# Altium schematic sheet Relais sheet.SchDoc
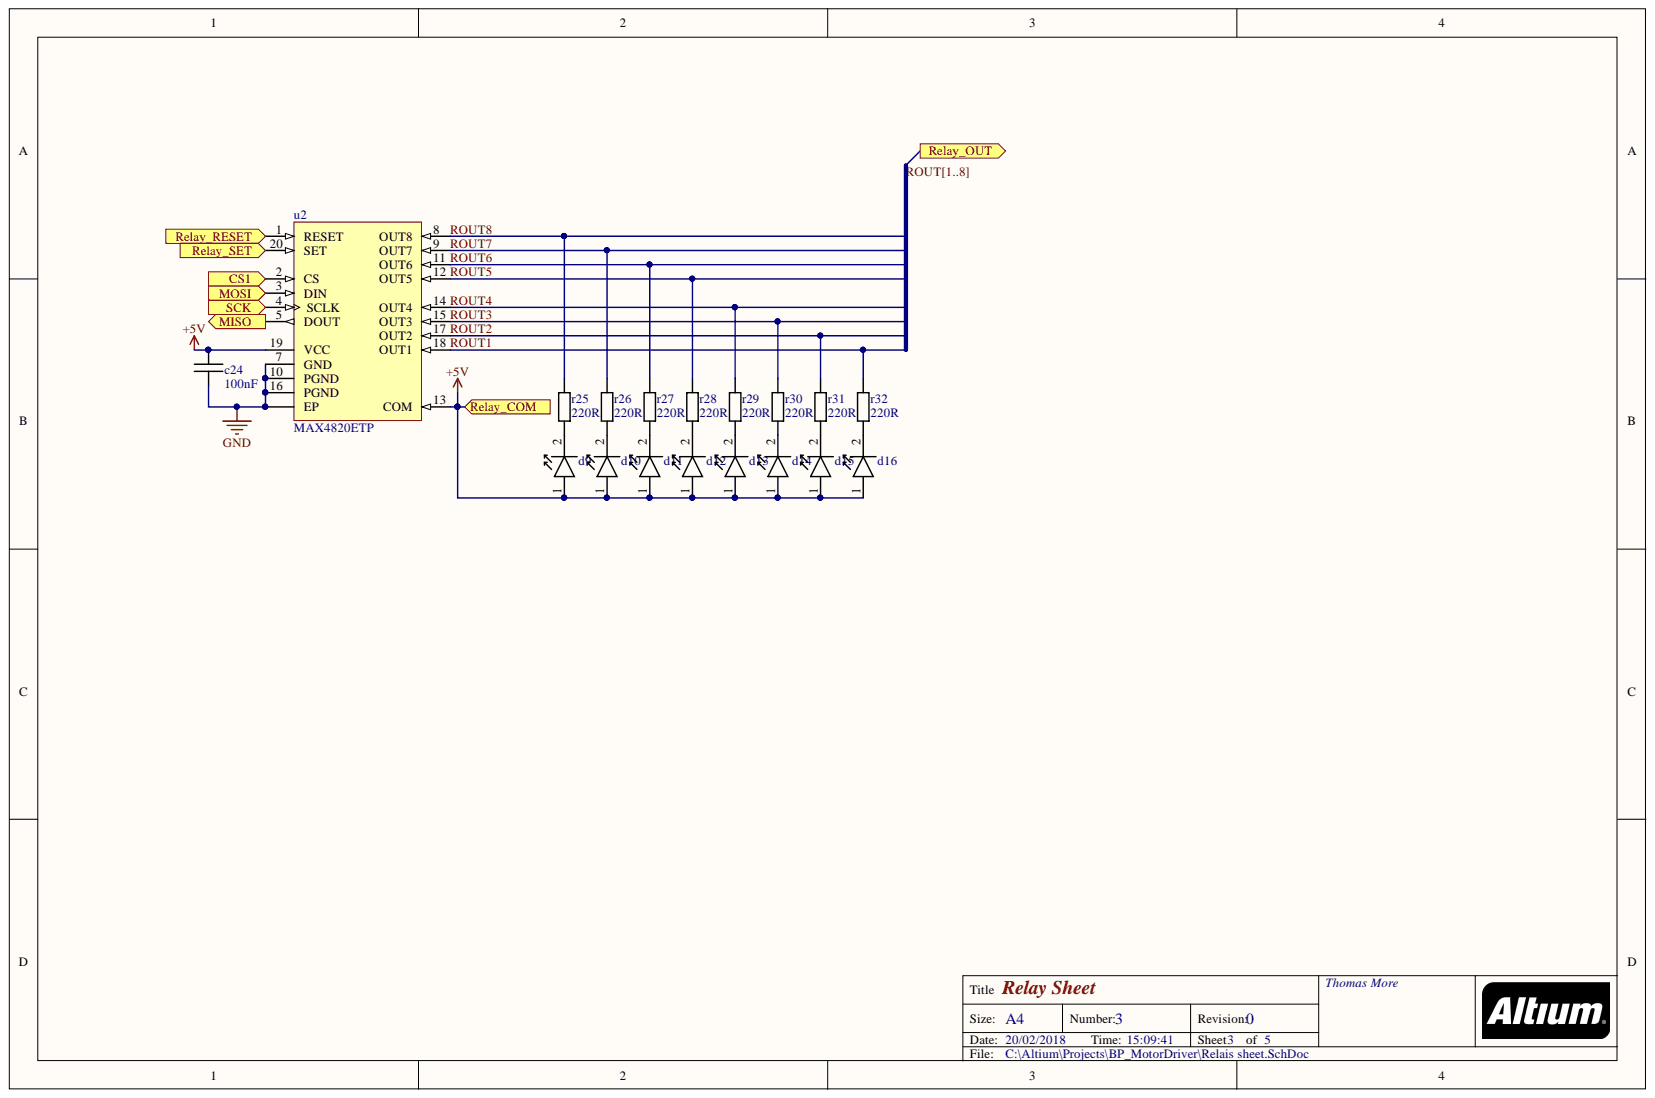
[diagram p0: Relais sheet.SchDoc]

## Parts
c24  100nF  [0805]
d9  Yellow SMD LED  [0603 - LED]  (value not drawn)
d10  Yellow SMD LED  [0603 - LED]  (value not drawn)
d11  Yellow SMD LED  [0603 - LED]  (value not drawn)
d12  Yellow SMD LED  [0603 - LED]  (value not drawn)
d13  Yellow SMD LED  [0603 - LED]  (value not drawn)
d14  Yellow SMD LED  [0603 - LED]  (value not drawn)
d15  Yellow SMD LED  [0603 - LED]  (value not drawn)
d16  Yellow SMD LED  [0603 - LED]  (value not drawn)
r25  220R  [0603]
r26  220R  [0603]
r27  220R  [0603]
r28  220R  [0603]
r29  220R  [0603]
r30  220R  [0603]
r31  220R  [0603]
r32  220R  [0603]
u2  MAX4820ETP  [20 Thin QFN-EP]

## Ports
CS1, MISO, MOSI, Relay_COM, Relay_OUT, Relay_RESET, Relay_SET, SCK

## Nets
GND: c24.2 u2.0 u2.7(GND) u2.10(PGND) u2.16(PGND)
+5V: c24.1 d9.1 d10.1 d11.1 d12.1 d13.1 d14.1 d15.1 d16.1 u2.13(COM) u2.19(VCC) ; port Relay_COM
CS1: u2.2(CS) ; port CS1
MISO: u2.5(DOUT) ; port MISO
MOSI: u2.3(DIN) ; port MOSI
Relay_RESET: u2.1(RESET) ; port Relay_RESET
Relay_SET: u2.20(SET) ; port Relay_SET
ROUT1: r32.2 u2.18(OUT1)  [also labelled ROUT]
ROUT2: r31.2 u2.17(OUT2)  [also labelled ROUT]
ROUT3: r30.2 u2.15(OUT3)  [also labelled ROUT]
ROUT4: r29.2 u2.14(OUT4)  [also labelled ROUT]
ROUT5: r28.2 u2.12(OUT5)  [also labelled ROUT]
ROUT6: r27.2 u2.11(OUT6)  [also labelled ROUT]
ROUT7: r26.2 u2.9(OUT7)  [also labelled ROUT]
ROUT8: r25.2 u2.8(OUT8)  [also labelled ROUT]
SCK: u2.4(SCLK) ; port SCK
Netd9_2: d9.2 r25.1
Netd10_2: d10.2 r26.1
Netd11_2: d11.2 r27.1
Netd12_2: d12.2 r28.1
Netd13_2: d13.2 r29.1
Netd14_2: d14.2 r30.1
Netd15_2: d15.2 r31.1
Netd16_2: d16.2 r32.1
N00224: ; port Relay_OUT

## Library data of the parts
c24 = 0805 Capacitor: ...F; Component Kind: Standard; Library Name: Generic R-C.SchLib
d9-d16 = Yellow SMD LED: 0603 Package; Component Kind: Standard; Library Name: Kingbright.SchLib
r25-r32 = 0603 Resistor: ...R; Component Kind: Standard; Library Name: Generic R-C.SchLib
u2 = MAX4820ETP: 20 TQFN-EP; Component Kind: Standard; Library Name: Maxim.SchLib
